# Altium schematic sheet CUBETTO.SchDoc(CUBETTO)
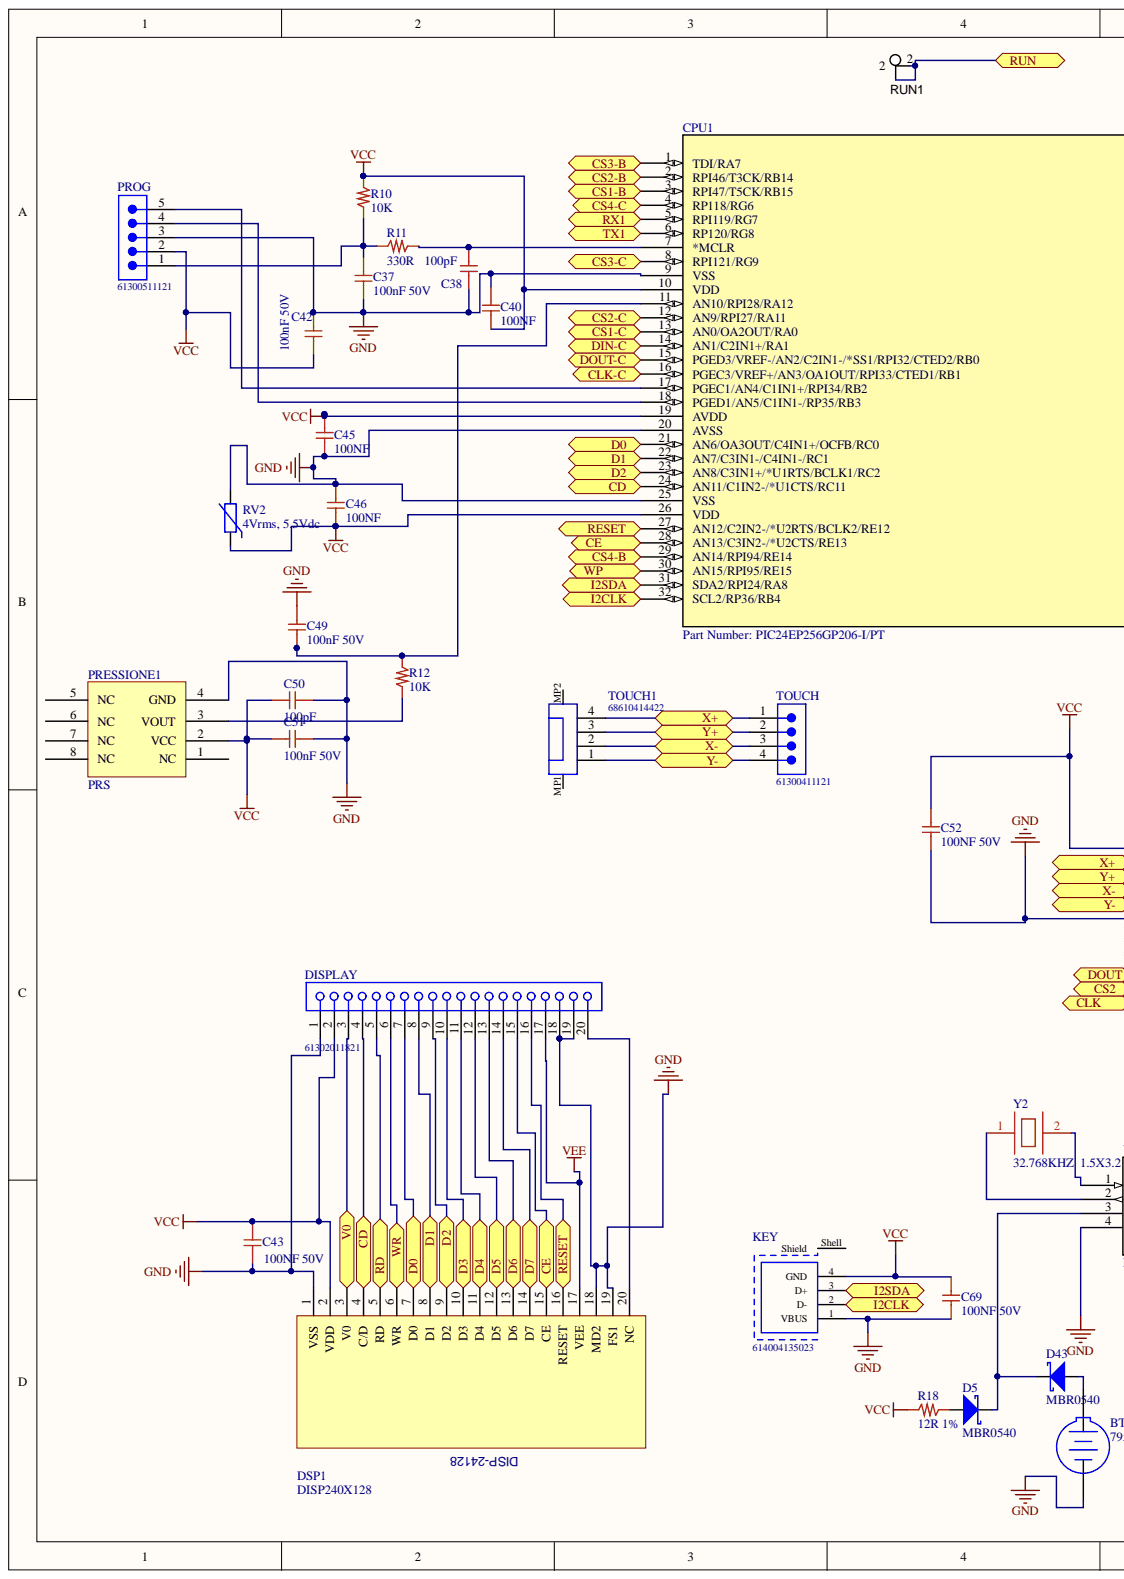
[diagram p0: CUBETTO.SchDoc, left]
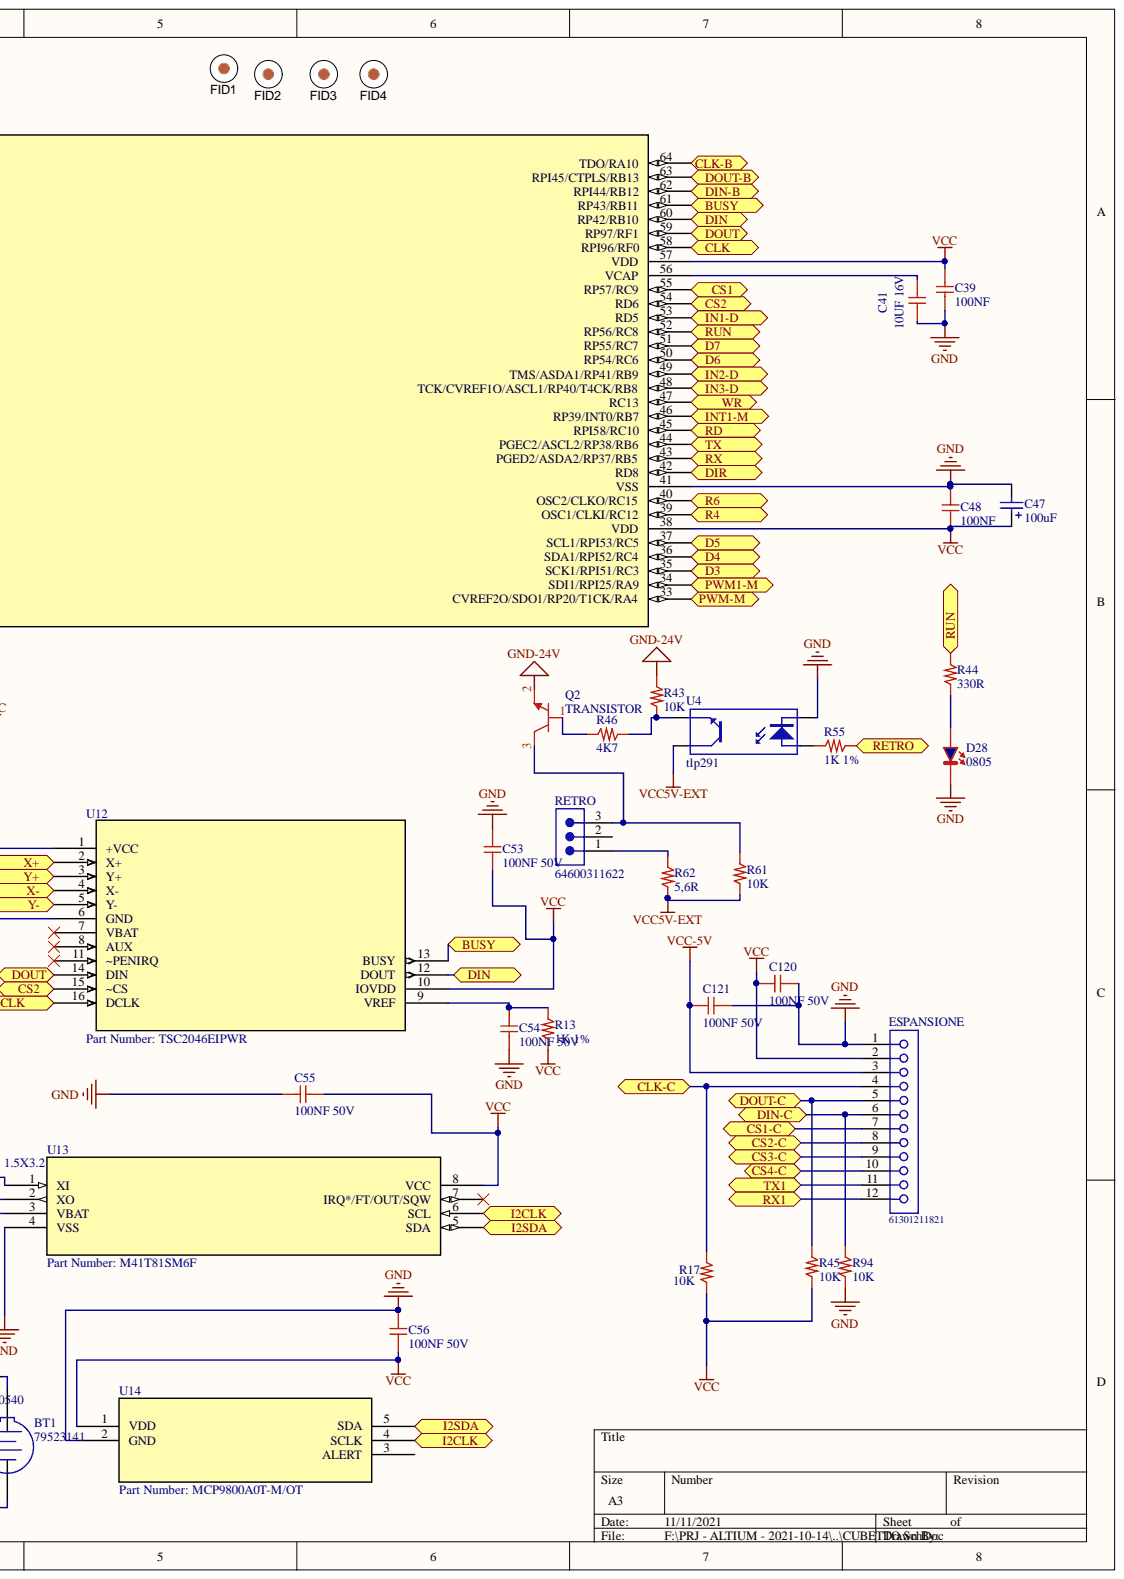
[diagram p1: CUBETTO.SchDoc, right]

## Parts
BT1  79523141  [79523141]
C37  100nF 50V  [0603_c]
C38  100pF  [0603_c]
C39  100NF  [0402_C]
C40  100NF  [0402_C]
C41  10UF 16V  [0805_C]
C42  100nF 50V  [0603_c]
C43  100NF 50V  [0603_c]
C45  100NF  [0402_C]
C46  100NF  [0402_C]
C47  value 100uF  [WCAP-ASLL_6.3x5.5]  (hidden comment: 865060143004)
C48  100NF  [0402_C]
C49  100nF 50V  [0603_c]
C50  100pF  [0603_c]
C51  100nF 50V  [0603_c]
C52  100NF 50V  [0603_c]
C53  100NF 50V  [0603_c]
C54  100NF 50V  [0603_c]
C55  100NF 50V  [0603_c]
C56  100NF 50V  [0603_c]
C69  100NF 50V  [0603_c]
C120  100NF 50V  [0603_c]
C121  100NF 50V  [0603_c]
CPU1  Part Number: PIC24EP256GP206-I/PT  [TQFP64_10X10MC]  (value not drawn)
D5  MBR0540  [SOD123]
D28  0805  [0805-BLU]
D43  MBR0540  [SOD123]
DISPLAY  61302011821  [61302011821]
DSP1  DISP240X128  [DISP-240X128]
ESPANSIONE  61301211821  [61301211821]
FID1  Fiducial  [Fiducial10-20]  (value not drawn)
FID2  Fiducial  [Fiducial10-20]  (value not drawn)
FID3  Fiducial  [Fiducial10-20]  (value not drawn)
FID4  Fiducial  [Fiducial10-20]  (value not drawn)
KEY  614004135023  [614004135023]
PRESSIONE1  PRS  [PRESS-HSC-A]
PROG  61300511121  [61300511121]
Q2  TRANSISTOR  [SOT23]
R10  10K  [0603_R]
R11  330R  [0603_R]
R12  10K  [0603_R]
R13  1K 1%  [0603_R]
R17  10K  [0603_R]
R18  12R 1%  [1206_R]
R43  10K  [0603_R]
R44  330R  [0603_R]
R45  10K  [0603_R]
R46  4K7  [0603_R]
R55  1K 1%  [0603_R]
R61  10K  [0603_R]
R62  5,6R  [1206_R]
R94  10K  [0603_R]
RETRO  64600311622  [64600311622]
RUN1  5012  [Keystone5012]  (value not drawn)
RV2  4Vrms, 5.5Vdc  [WE-VS_0603]
TOUCH  61300411121  [61300411121]
TOUCH1  68610414422  [68610414422]
U4  tlp291  [SO4_N]
U12  Part Number: TSC2046EIPWR  [PW16]
U13  Part Number: M41T81SM6F  [SO-8]
U14  Part Number: MCP9800A0T-M/OT  [SOT-23-5_MC]
Y2  32.768KHZ  1.5X3.2  [CRIS 3.2X1.5]

## Ports
BUSY, CD, CE, CLK, CLK-B, CLK-C, CS1, CS1-B, CS1-C, CS2, CS2-B, CS2-C, CS3-B, CS3-C, CS4-B, CS4-C, D0, D1, D2, D3, D4, D5, D6, D7, DIN, DIN-B, DIN-C, DIR, DOUT, DOUT-B, DOUT-C, I2CLK, I2SDA, IN1-D, IN2-D, IN3-D, INT1-M, PWM1-M, PWM-M, R4, R6, RD, RESET, RETRO, RUN, RX, RX1, TX, TX1, V0, WP, WR, X+, X-, Y+, Y-

## Nets
GND: BT1.2 C37.2 C38.2 C39.2 C40.1 C41.2 C42.1 C43.2 C45.2 C46.1 C47.2 C48.1 C49.1 C50.2 C51.2 C52.2 C53.1 C54.2 C55.2 C56.2 C69.1 C120.2 C121.2 CPU1.9(VSS) CPU1.20(AVSS) CPU1.25(VSS) CPU1.41(VSS) D28.K DISPLAY.1 DISPLAY.18 DISPLAY.19 DSP1.1(VSS) DSP1.18(MD2) DSP1.19(FS1) ESPANSIONE.1 KEY.1(VBUS) PRESSIONE1.4(GND) PROG.3 R94.1 RV2.2 U4.2 U12.6(GND) U13.4(VSS) U14.2(GND)
GND-24V: Q2.2 R43.2
VCC: C39.1 C40.2 C42.2 C43.1 C45.1 C46.2 C47.1 C48.2 C50.1 C51.1 C52.1 C53.2 C55.1 C56.1 C69.2 C120.1 CPU1.10(VDD) CPU1.19(AVDD) CPU1.26(VDD) CPU1.38(VDD) CPU1.57(VDD) DISPLAY.2 DSP1.2(VDD) ESPANSIONE.2 KEY.4(GND) PRESSIONE1.2(VCC) PROG.2 R10.1 R13.2 R17.1 R18.1 R45.1 RV2.1 U12.1(+VCC) U12.10(IOVDD) U13.8(VCC) U14.1(VDD)
VCC5V-EXT: R61.1 R62.1 U4.4
VCC-5V: C121.1 ESPANSIONE.3
VEE: DISPLAY.17 DSP1.17(VEE)
BUSY: CPU1.61(RP43/RB11) U12.13(BUSY) ; port BUSY
CD: CPU1.24(AN11/C1IN2-/*U1CTS/RC11) DISPLAY.4 DSP1.4(C/D) ; port CD
CE: CPU1.28(AN13/C3IN2-/*U2CTS/RE13) DISPLAY.15 DSP1.15(CE) ; port CE
CLK: CPU1.58(RPI96/RF0) U12.16(DCLK) ; port CLK
CLK-B: CPU1.64(TDO/RA10) ; port CLK-B
CLK-C: CPU1.16(PGEC3/VREF+/AN3/OA1OUT/RPI33/CTED1/RB1) ESPANSIONE.4 R17.2 ; port CLK-C
CS1: CPU1.55(RP57/RC9) ; port CS1
CS1-B: CPU1.3(RPI47/T5CK/RB15) ; port CS1-B
CS1-C: CPU1.13(AN0/OA2OUT/RA0) ESPANSIONE.7 ; port CS1-C
CS2: CPU1.54(RD6) U12.15(~CS) ; port CS2
CS2-B: CPU1.2(RPI46/T3CK/RB14) ; port CS2-B
CS2-C: CPU1.12(AN9/RPI27/RA11) ESPANSIONE.8 ; port CS2-C
CS3-B: CPU1.1(TDI/RA7) ; port CS3-B
CS3-C: CPU1.8(RPI121/RG9) ESPANSIONE.9 ; port CS3-C
CS4-B: CPU1.29(AN14/RPI94/RE14) ; port CS4-B
CS4-C: CPU1.4(RP118/RG6) ESPANSIONE.10 ; port CS4-C
D0: CPU1.21(AN6/OA3OUT/C4IN1+/OCFB/RC0) DISPLAY.7 DSP1.7(D0) ; port D0
D1: CPU1.22(AN7/C3IN1-/C4IN1-/RC1) DISPLAY.8 DSP1.8(D1) ; port D1
D2: CPU1.23(AN8/C3IN1+/*U1RTS/BCLK1/RC2) DISPLAY.9 DSP1.9(D2) ; port D2
D3: CPU1.35(SCK1/RPI51/RC3) DISPLAY.10 DSP1.10(D3) ; port D3
D4: CPU1.36(SDA1/RPI52/RC4) DISPLAY.11 DSP1.11(D4) ; port D4
D5: CPU1.37(SCL1/RPI53/RC5) DISPLAY.12 DSP1.12(D5) ; port D5
D6: CPU1.50(RP54/RC6) DISPLAY.13 DSP1.13(D6) ; port D6
D7: CPU1.51(RP55/RC7) DISPLAY.14 DSP1.14(D7) ; port D7
DIN: CPU1.60(RP42/RB10) U12.12(DOUT) ; port DIN
DIN-B: CPU1.62(RPI44/RB12) ; port DIN-B
DIN-C: CPU1.14(AN1/C2IN1+/RA1) ESPANSIONE.6 R94.2 ; port DIN-C
DIR: CPU1.42(RD8) ; port DIR
DOUT: CPU1.59(RP97/RF1) U12.14(DIN) ; port DOUT
DOUT-B: CPU1.63(RPI45/CTPLS/RB13) ; port DOUT-B
DOUT-C: CPU1.15(PGED3/VREF-/AN2/C2IN1-/*SS1/RPI32/CTED2/RB0) ESPANSIONE.5 R45.2 ; port DOUT-C
I2CLK: CPU1.32(SCL2/RP36/RB4) KEY.2(D-) U13.6(SCL) U14.4(SCLK) ; port I2CLK
I2SDA: CPU1.31(SDA2/RPI24/RA8) KEY.3(D+) U13.5(SDA) U14.5(SDA) ; port I2SDA
IN1-D: CPU1.53(RD5) ; port IN1-D
IN2-D: CPU1.49(TMS/ASDA1/RP41/RB9) ; port IN2-D
IN3-D: CPU1.48(TCK/CVREF1O/ASCL1/RP40/T4CK/RB8) ; port IN3-D
INT1-M: CPU1.46(RP39/INT0/RB7) ; port INT1-M
PWM1-M: CPU1.34(SDI1/RPI25/RA9) ; port PWM1-M
PWM-M: CPU1.33(CVREF2O/SDO1/RP20/T1CK/RA4) ; port PWM-M
R4: CPU1.39(OSC1/CLKI/RC12) ; port R4
R6: CPU1.40(OSC2/CLKO/RC15) ; port R6
RD: CPU1.45(RPI58/RC10) DISPLAY.5 DSP1.5(RD) ; port RD
RESET: CPU1.27(AN12/C2IN2-/*U2RTS/BCLK2/RE12) DISPLAY.16 DSP1.16(RESET) ; port RESET
RETRO: R55.2 ; port RETRO
RUN: CPU1.52(RP56/RC8) R44.1 RUN1.1 RUN1.2 ; port RUN
RX: CPU1.43(PGED2/ASDA2/RP37/RB5) ; port RX
RX1: CPU1.5(RPI119/RG7) ESPANSIONE.12 ; port RX1
TX: CPU1.44(PGEC2/ASCL2/RP38/RB6) ; port TX
TX1: CPU1.6(RP120/RG8) ESPANSIONE.11 ; port TX1
V0: DISPLAY.3 DSP1.3(V0) ; port V0
WP: CPU1.30(AN15/RPI95/RE15) ; port WP
WR: CPU1.47(RC13) DISPLAY.6 DSP1.6(WR) ; port WR
NetBT1_1: BT1.1 D43.1
NetC37_1: C37.1 PROG.1 R10.2 R11.1
NetC38_1: C38.1 CPU1.7(*MCLR) R11.2
NetC41_1: C41.1 CPU1.56(VCAP)
NetC49_2: C49.2 CPU1.11(AN10/RPI28/RA12) R12.2
NetC54_1: C54.1 R13.1 U12.9(VREF)
NetCPU1_17: CPU1.17(PGEC1/AN4/C1IN1+/RPI34/RB2) PROG.5
NetCPU1_18: CPU1.18(PGED1/AN5/C1IN1-/RP35/RB3) PROG.4
NetD5_1: D5.1 R18.2
NetD5_2: D5.2 D43.2 U13.3(VBAT)
NetD28_A: D28.A R44.2
NetDISPLAY_20: DISPLAY.20 DSP1.20(NC)
NetPRESSIONE1_3: PRESSIONE1.3(VOUT) R12.1
NetQ2_1: Q2.1 R46.1
NetQ2_3: Q2.3 R61.2 RETRO.3
NetR43_1: R43.1 R46.2 U4.3
NetR55_1: R55.1 U4.1
NetR62_2: R62.2 RETRO.1
NetTOUCH1_1: TOUCH.4 TOUCH1.1 U12.5(Y-)
NetTOUCH1_2: TOUCH.3 TOUCH1.2 U12.4(X-)
NetTOUCH1_3: TOUCH.2 TOUCH1.3 U12.3(Y+)
NetTOUCH1_4: TOUCH.1 TOUCH1.4 U12.2(X+)
NetU13_1: U13.1(XI) Y2.2
NetU13_2: U13.2(XO) Y2.1

Not connected: KEY Shell(Shield); PRESSIONE1 1(NC) 5(NC) 6(NC) 7(NC) 8(NC); RETRO 2; TOUCH1 MP1 MP2; U12 7(VBAT) 8(AUX) 11(~PENIRQ); U13 7(IRQ*/FT/OUT/SQW); U14 3(ALERT)

## Library data of the parts
BT1 = 79523141: WA-BCMC Battery Holder, SMT, Battery Type 2016/2020/2025/2032; Published Date: September 2019; Part Number: 79523141; Packaging: Tape and Reel; Mounting Technology: SMT; Library Name: WA-BCMC.SchLib; ComponentLink2URL: https://katalog.we-online.com/em/datasheet/79523141.pdf; ComponentLink2Description: Datasheet; ComponentLink1URL: http://www.we-online.de; ComponentLink1Description: Manufacturer; Component Kind: Standard; Battery Type: 2016/2020/2025/2032; Publisher: Wurth Elektronik
C37 C42 C43 C49 C51-C56 C69 C120 C121 = 100NF 50V: Cap X7R 100nF 50V Flex Terminal; Produttore: Samsung; Cod. Produttore: CL10B104KB8SFNC; Cod. Interno: COCS50665050104; Library Name: CapSMD0603.SchLib; Component Kind: Standard; Cod. DigiKey: 1276-1936-2-ND
C38 C50 = 100pF 50V: Cap X7R 100pF 50V; Produttore: ??; Cod. Interno: COCS??; Library Name: CapSMD0603.SchLib; Component Kind: Standard; Cod. Produttore: ??
C39 C40 C45 C46 C48 = 100NF 16V: Cap X7R 100NF 16V; Produttore: ??; Cod. Interno: COCS556561600104; Library Name: CapSMD0402.SchLib; Component Kind: Standard; Cod. Produttore: ??
C41 = 10UF 16V: Cap X5R 10UF 16V; Produttore: TDK; Cod. Produttore: C2012X6S1C106K125AC; Cod. Interno: COCS517061600106; Temperature Range: Da -55°C a +105°C; Library Name: CapSMD0805.SchLib; Component Kind: Standard; Cod. DigiKey: 445-7611-2-ND
C47 = 865060143004: WCAP-ASLL Aluminum Electrolytic Capacitors, size Ф6.3x5.5mm, 6.3V DC, 100uF; Rated Voltage: 6.3V DC; Size: Ф6.3x5.5mm; Published Date: June 2017; Part Number: 865060143004; Operating Temperature: -55 °C up to +105 °C; Life Cycle: 2000h; Library Name: WCAP-ASLL.SchLib; ComponentLink2URL: http://katalog.we-online.de/pbs/datasheet/865060143004.pdf; ComponentLink2Description: Datasheet; ComponentLink1URL: http://www.we-online.de; ComponentLink1Description: Manufacturer; Component Kind: Standard; Publisher: Wurth Elektronik
CPU1 = PIC24EP256GP206-: No Description Available; Vendor: Microchip; Built_By: EMA_UL_Team; Value: Value; Type: Type; RefDes: RefDes; Manufacturer_Part_Number: PIC24EP256GP206-I/PT; Library Name: PIC24EP256GP206.SchLib; Component Kind: Standard; Copyright: Copyright (C) 2018 Accelerated Designs. All rights reserved
D5 D43 = MBR0540: Schottky Diode; Simulation: SKYDIODE; Signal Integrity: D Schottky; Published: 8-Jun-2000; PackageReference: SMB; PackageDescription: DO-214-AA/SMB; 2 C-Bend Leads; Body 5.3 x 3.6 mm, inc. leads (LxW); LatestRevisionNote: Re-released for DXP Platform.; LatestRevisionDate: 17-Jul-2002; Component Kind: Standard; Code_JEDEC: DO-214-AA; Publisher: Altium Limited; Library Name: HSW.SchLib
D28 = LED BLU 0805: Vf(typ): 3.3V; Produttore: kingbright; Luminosità: 100mcd 20mA; Cod. Produttore: KPTR-3216QBC-D; Description: LED BLU 0805; Component Kind: Standard; Library Name: DiodiLED.SchLib
DISPLAY = 61302011821: WR-PHD Socket Header, THT, pitch 2.54mm, Single Row, Vertical, 20p; Manufacturer: Wurth Elektronik; Working Voltage: 250VAC; Withstanding Voltage: 500VAC; Rated Current: 3A; Pin Number: 20; Contact Resistance: 20mOhm; Row Number: Single; Published Date: May 2020; Pitch: 2.54mm; Packaging: Tray; Orientation: Vertical; Operating Temperature: -40 to +125degC; Mounting Technology: THT; Manufacturer Part Number: 61302011821; Library Name: WR-PHD_Socket Header_2.54mm.SchLib; Gender: Socket Header; ComponentLink2URL: https://www.we-online.com/catalog/datasheet/61302011821.pdf; ComponentLink2Description: Datasheet; ComponentLink1URL: http://www.we-online.de; ComponentLink1Description: Manufacturer; Component Kind: Standard
DSP1 = DISP-240X128: DISPLAY WINSTAR 240X128-WG240128B; Component Kind: Standard; Library Name: HSW.SchLib
ESPANSIONE = 61301211821: WR-PHD Socket Header, THT, pitch 2.54mm, Single Row, Vertical, 12p; Manufacturer: Wurth Elektronik; Working Voltage: 250VAC; Withstanding Voltage: 500VAC; Rated Current: 3A; Pin Number: 12; Contact Resistance: 20mOhm; Row Number: Single; Published Date: May 2020; Pitch: 2.54mm; Packaging: Tray; Orientation: Vertical; Operating Temperature: -40 to +125degC; Mounting Technology: THT; Manufacturer Part Number: 61301211821; Library Name: WR-PHD_Socket Header_2.54mm.SchLib; Gender: Socket Header; ComponentLink2URL: https://www.we-online.com/catalog/datasheet/61301211821.pdf; ComponentLink2Description: Datasheet; ComponentLink1URL: http://www.we-online.de; ComponentLink1Description: Manufacturer; Component Kind: Standard
FID1-FID4 = Fiducial: Fiducial mark.  There is nothing to buy or mount.; SymbolCreator: codlsc; SymbolVersion: 2009-11-4; PartNumber: N/A; PackageReference: N/A; MountType: N/A; Manufacturer: N/A; LatestRevisionNote: Added Alternate PartNumber and Alternate Manufacturer parameters to appropriate components.; LatestRevisionDate: 2015-10-18; CompType: Fiducial; Component Kind: Standard; ClassName: FiducialMark; Publisher: Texas Instruments; Library Name: HSW.SchLib
KEY = 614004135023: USB 2.0 Type A Receptacle WR-COM, Vertical, THT; Working Voltage: 30 VAC; Rated Current: 1.5 A; Contact Resistance: 20 mOhm; Published Date: September 2018; Part Number: 614004135023; Packaging: Tray; Orientation: Vertical; Operating Temperature: -40 to 105 degC; Mounting Technology: THT; Library Name: USB Connectors WR-COM.SchLib; Gender: Receptacle; Connector Type: USB 2.0 Type A; ComponentLink2URL: https://katalog.we-online.de/em/datasheet/614004135023.pdf; ComponentLink2Description: Datasheet; ComponentLink1URL: http://www.we-online.de; ComponentLink1Description: Manufacturer; Component Kind: Standard; Publisher: Wurth Elektronik
PRESSIONE1 = PRESS-HSC-AN: Component Kind: Standard; Library Name: HSW.SchLib
PROG = 61300511121: THT Vertical Pin Header WR-PHD, Pitch 2.54 mm, Single Row, 5 pins; Working Voltage: 250 VAC; Withstanding Voltage: 500 VAC; Rated Current: 3 A; Pitch: 2.54 mm; Pin Number: 5; Gender: Male; Contact Resistance: 20 mOhm; Row Number: Single; Published Date: August 2018; Part Number: 61300511121; Packaging: Bag; Orientation: Vertical; Operating Temperature: -40 to 105 degC; Mounting Technology: THT; Library Name: Pin Header WR-PHD_2.54 mm.SchLib; ComponentLink2URL: https://katalog.we-online.de/em/datasheet/6130xx11121.pdf; ComponentLink2Description: Datasheet; ComponentLink1URL: http://www.we-online.de; ComponentLink1Description: Manufacturer; Component Kind: Standard; Publisher: Wurth Elektronik
Q2 = BC847B: NPN Silicon Darlington Transistors; Vce: 30V; Vcb: 40V; Produttore: INFINEON; Ic: 500mA; Cod. Produttore: BCV27; Cod. Interno: TRXS ??; Component Kind: Standard; Library Name: HSW.SchLib
R10 R12 R17 R43 R45 R61 R94 = 2K7: Res Film Spesso 1/16W 2K7 5%; Produttore: VISHAY; Cod. Produttore: CRCW06032K70JNEA; Cod. Interno: RESS4166556B0272; Library Name: ResSMD0603.SchLib; Component Kind: Standard
R11 R44 = 330R: Res Film Spesso 1/16W 330R 5%; Cod. Interno: RESS4166556B0331; Library Name: ResSMD0603.SchLib; Component Kind: Standard
R13 R55 = 1K 1%: Res Film Spesso 1/16W 1K 1%; Produttore: ??; Cod. Interno: RESS4166356B0102; Library Name: ResSMD0603.SchLib; Component Kind: Standard; Cod. Produttore: ??
R18 = 12R 1%: 12R 1% 1206 1/4W; Produttore: Yageo; Cod. Produttore: RC1206FR-0712RL; Library Name: ResSMD1206.SchLib; Component Kind: Standard; Cod. DigiKey: 311-12.0FRCT-ND; Case: 1206
R46 = 4K7: Res Film Spesso 1/16W 4K7 5%; Cod. Interno: RESS4166556B0472; Library Name: ResSMD0603.SchLib; Component Kind: Standard
R62 = 5,6R: 5,6R 1206 1/4W; Cod. Interno: RESS 4173525B03R3; Library Name: ResSMD1206.SchLib; Component Kind: Standard; Case: 1206
RETRO = 64600311622: WR-WTB Male Vertical Shrouded Header, pitch 2.5mm, 3p; Working Voltage: 250VAC; Withstanding Voltage: 1000VAC; Rated Current: 3A; Pin Number: 3; Contact Resistance: 20mOhm; Type: Vertical; Published Date: January 2020; Pitch: 2.5mm; Part Number: 64600311622; Packaging: Bag; Operating Temperature: -40 to +105degC; Mounting Technology: THT; Library Name: WR-WTB_2.50mm.SchLib; Gender: Male; ComponentLink2URL: https://www.we-online.com/catalog/datasheet/64600311622.pdf; ComponentLink2Description: Datasheet; ComponentLink1URL: http://www.we-online.de; ComponentLink1Description: Manufacturer; Component Kind: Standard; Publisher: Wurth Elektronik
RUN1 = 5012_1: Test Point, Multipurpose, White, TH; Manufacturer: Keystone; ContactFinish: Silver; CompType: Test Point Loop; SymbolVersion: 2008-04-04; Supplier Part Number 2: 534-5012; Supplier Part Number 1: 36-5012-ND; Supplier 2: Mouser; Supplier 1: Digi-Key; RoHS: Y; PartNumber: 5012; PackageReference: White Multipurpose Testpoint; MountType: TH; LatestRevisionDate: 2017-01-19; ComponentLink1URL: http://www.keyelco.com/userAssets/file/M60-2p60.pdf; ComponentLink1Description: Datasheet URL; Component Kind: Standard; Color: White; Publisher: Texas Instruments; Library Name: HSW.SchLib
RV2 = 82536040: WE-VS SMD Varistor, size 0603, 4Vrms, 5.5Vdc; Withstanding Surge Current: 30A; Varistor Voltage: 8V; Energy Dissipation: 0.1J; Clamping Voltage: 21V; Size: 0603; Published Date: March 2019; Part Number: 82536040; Packaging: Tape and Reel; Operating Temperature: -40 to +85degC; Mounting Technology: SMT; Library Name: WE-VS_0603.SchLib; DC Operating Voltage: 5.5Vdc; ComponentLink2URL: https://katalog.we-online.com/pbs/datasheet/82536040.pdf; ComponentLink2Description: Datasheet; ComponentLink1URL: http://www.we-online.de; ComponentLink1Description: Manufacturer; Component Kind: Standard; AC Operating Voltage: 4Vrms; Publisher: Wurth Elektronik
TOUCH = 61300411121: THT Vertical Pin Header WR-PHD, Pitch 2.54 mm, Single Row, 4 pins; Working Voltage: 250 VAC; Withstanding Voltage: 500 VAC; Rated Current: 3 A; Pitch: 2.54 mm; Pin Number: 4; Gender: Male; Contact Resistance: 20 mOhm; Row Number: Single; Published Date: August 2018; Part Number: 61300411121; Packaging: Bag; Orientation: Vertical; Operating Temperature: -40 to 105 degC; Mounting Technology: THT; Library Name: Pin Header WR-PHD_2.54 mm.SchLib; ComponentLink2URL: https://katalog.we-online.de/em/datasheet/6130xx11121.pdf; ComponentLink2Description: Datasheet; ComponentLink1URL: http://www.we-online.de; ComponentLink1Description: Manufacturer; Component Kind: Standard; Publisher: Wurth Elektronik
TOUCH1 = 68610414422: SMT ZIF Connectors WR-FPC, Horizontal, Bottom Contact, pitch 1 mm, 4 pins; Working Voltage: 125 VAC; Withstanding Voltage: 500 VAC; Rated Current: 1 A; Pitch: 1 mm; Pin Number: 4; Contact Resistance: 20 mOhm; Published Date: August 2018; Part Number: 68610414422; Packaging: Tape and Reel; Orientation: Horizontal; Operating Temperature: -25 to 85 degC; Mounting Technology: SMT; Library Name: ZIF Connectors WR-FPC_1.00 mm.SchLib; Contact Design: Bottom Contact; ComponentLink2URL: https://katalog.we-online.de/em/datasheet/68610414422.pdf; ComponentLink2Description: Datasheet; ComponentLink1URL: http://www.we-online.de; ComponentLink1Description: Manufacturer; Component Kind: Standard; Publisher: Wurth Elektronik
U4 = tlp291: 4-Pin Phototransistor Optocoupler; Published: 24-Mar-1999; PackageReference: DIP-4; LatestRevisionNote: Sch pins 3 & 4 Swapped.; LatestRevisionDate: 04-Mar-2005; DatasheetVersion: Nov-2003; Component Kind: Standard; Publisher: Altium Limited; Library Name: HSW.SchLib
U12 = TSC2046EIPWR: No Description Available; Vendor: Texas Instruments; Built_By: EMA_UL_Team; Value: Value; Type: DEV; RefDes: RefDes; Manufacturer_Part_Number: TSC2046EIPWR; Library Name: TSC2046.SchLib; Component Kind: Standard; Copyright: Copyright (C) 2018 Accelerated Designs. All rights reserved
U13 = M41T81SM6F: No Description Available; Vendor: STMicroelectronics; Built_By: EMA_UL_Team; Value: Value; Type: DEV; RefDes: RefDes; Manufacturer_Part_Number: M41T81SM6F; Library Name: M41T81.SchLib; Component Kind: Standard; Copyright: Copyright (C) 2018 Accelerated Designs. All rights reserved
U14 = MCP9800A0T-M/OT: No Description Available; Vendor: Microchip; Built_By: EMA_UL_Team; Value: Value; Type: DEV; RefDes: RefDes; Manufacturer_Part_Number: MCP9800A0T-M/OT; Library Name: MCP9800.SchLib; Component Kind: Standard; Copyright: Copyright (C) 2018 Accelerated Designs. All rights reserved
Y2 = QUARZO 32.768KHZ  1.5X3.2: QUARZO SMD 32.768KHZ 1.5X3.2; Produttore: TXC; Costo 1000: 0.301; Costo 100: 0.326; Cod. Produttore: 9HT10-32.768KDZB-T; Cod. Interno: ??; Library Name: OSC.SchLib; Component Kind: Standard; Cod. Farnell: 2819301
